# Altium schematic sheet SCHEMATIC.SchDoc(SCHEMATIC)
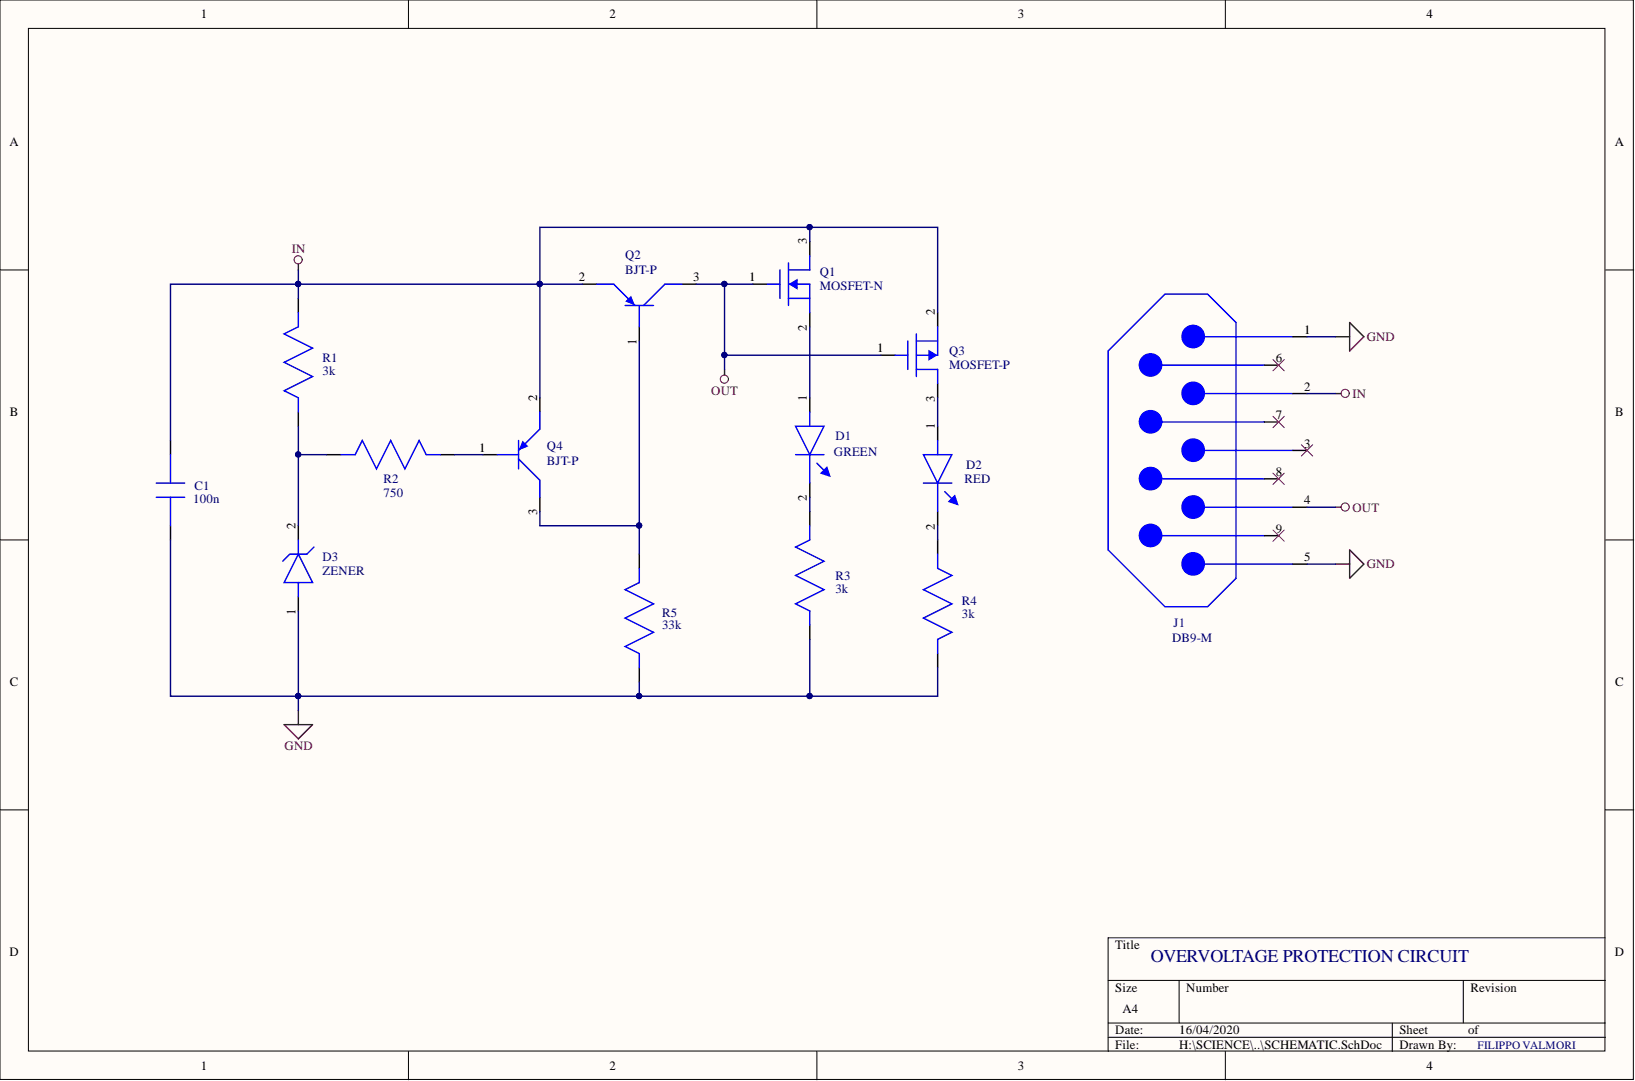
[diagram p0: SCHEMATIC.SchDoc]

## Parts
C1  100n  [CAP-0603]
D1  GREEN  [LED-0603]
D2  RED  [LED-0603]
D3  ZENER  [ZENER-SOD523]
J1  DB9-M  [DB9]
Q1  MOSFET-N  [NMOS-SOT23]
Q2  BJT-P  [BJT-SOT23]
Q3  MOSFET-P  [PMOS-SOT23]
Q4  BJT-P  [BJT-SOT23]
R1  3k  [RES-0805]
R2  750  [RES-0805]
R3  3k  [RES-0805]
R4  3k  [RES-0805]
R5  33k  [RES-0805]

## Nets
GND: C1.2 D3.1 J1.1 J1.5 R3.1 R4.2 R5.1
IN: C1.1 J1.2 Q1.3 Q2.2 Q3.2 Q4.2 R1.2
OUT: J1.4 Q1.1 Q2.3 Q3.1
NetD1_1: D1.1 Q1.2
NetD1_2: D1.2 R3.2
NetD2_1: D2.1 Q3.3
NetD2_2: D2.2 R4.1
NetD3_2: D3.2 R1.1 R2.1
NetQ2_1: Q2.1 Q4.3 R5.2
NetQ4_1: Q4.1 R2.2

Not connected: J1 3 6 7 8 9

## Library data of the parts
C1 = C0603C104M3RACTU: Multilayer Ceramic Capacitors MLCC - SMD/SMT 25volts 0.1uF X7R 20%; Manufacturer: KEMET; Voltage Rating (DC): 25V; Capacitance: 100nF; Width: 0.031inch; Voltage Rating: 25V; Tolerance: 20%; Thickness: 0.034inch; Termination: SMD/SMT; Supplier Part Number 1: 399-1283-6-ND; Supplier 1: Digi-Key; Stock 1: 15620; REACH SVHC: No SVHC; Radiation Hardening: No; Pricing 1: 1=0.1, 10=0.06, 100=0.0267, 500=0.01906, 1000=0.01497 (USD); Packaging: Tape and Reel; Package Quantity: 1; Number of Pins: 2; Mount: Surface Mount,PCB; Min Operating Temperature: -55°C; Max Operating Temperature: 125°C; Manufacturer Part Number: C0603C104M3RACTU; Library Name: LIBRARY_SCHEMATIC.SchLib; Length: 1.6mm; Lead Pitch: 0.7mm; Height: 0.8mm; Dielectric Material: Ceramic; Dielectric: X7R; Depth: 0.8mm; ComponentLink4URL: http://www.alliedelec.com/m/d/9bfe7773041ffe9d406e68bc7b377fdd.pdf?src-supplier=Allied+Electronics; ComponentLink4Description: http://www.alliedelec.com/m/d/9bfe7773041ffe9d406e68bc7b377fdd.pdf?src-supplier=Allied+Electronics; ComponentLink3URL: https://www.verical.com/server-webapp/html/getDatasheet?partID=344365&src-supplier=Verical; ComponentLink3Description: https://www.verical.com/server-webapp/html/getDatasheet?partID=344365&src-supplier=Verical; ComponentLink2URL: http://www.farnell.com/datasheets/2746054.pdf?src-supplier=Newark; ComponentLink2Description: http://www.farnell.com/datasheets/2746054.pdf?src-supplier=Newark; ComponentLink1URL: https://www.verical.com/server-webapp/html/getDatasheet?partID=58462&src-supplier=Verical; ComponentLink1Description: https://www.verical.com/server-webapp/html/getDatasheet?partID=58462&src-supplier=Verical; Component Kind: Standard; Category: Capacitors; Case Code (Metric): 1608; Case Code (Imperial): 0603; Case/Package: 0603
D1 = 598-8070-107F: DIALIGHT - 5988070107F - LED, Green, SMD, 0603, 20 mA, 2 V, 570 nm; Wavelength: 570nm; Viewing Angle: 140°; Test Current: 20mA; Reverse Voltage: 5V; Power Dissipation: 60mW; Number of LEDs: 1; Luminous Intensity: 20mcd; Lens Transparency: Clear; Lens Style: Flat,Rectangular,Diffused,Colorless,Clear; Lens Size: 0.8mm; Lens Color: Colorless,Clear; Forward Voltage: 2V; Dominant Wavelength: 568nm; Width: 0.8mm; Supplier Part Number 1: 350-2035-6-ND; Supplier 1: Digi-Key; Stock 1: 4673; REACH SVHC: No SVHC; Radiation Hardening: No; Pricing 1: 1=0.42, 10=0.3, 100=0.1333, 500=0.09332, 1000=0.07666 (USD); Packaging: Tape and Reel; Package Quantity: 4000; Number of Pins: 2; Number of Elements: 1; Mount: Surface Mount; Min Operating Temperature: -30°C; Max Operating Temperature: 100°C; Manufacturer Part Number: 598-8070-107F; Manufacturer: Dialight; Library Name: LIBRARY_SCHEMATIC.SchLib; Length: 1.6mm; Lead Free: Lead Free; Illumination Color: Green; Height: 0.7mm; Forward Current: 20mA; Depth: 0.8mm; ComponentLink8URL: http://www.dialight.com/Assets/Brochures_And_Catalogs/Indication/SMT_selector_guide.pdf?src-supplier=Future+Electronics; ComponentLink8Description: http://www.dialight.com/Assets/Brochures_And_Catalogs/Indication/SMT_selector_guide.pdf?src-supplier=Future+Electronics; ComponentLink7URL: https://www.verical.com/server-webapp/html/getDatasheet?partID=146438&src-supplier=Verical; ComponentLink7Description: https://www.verical.com/server-webapp/html/getDatasheet?partID=146438&src-supplier=Verical; ComponentLink6URL: http://www.onlinecomponents.com/productFiles/mf-DIA/mdei5980603.pdf?src-supplier=Onlinecomponents.com; ComponentLink6Description: http://www.onlinecomponents.com/productFiles/mf-DIA/mdei5980603.pdf?src-supplier=Onlinecomponents.com; ComponentLink5URL: https://www.alliedelec.com/m/d/745256ca487aea7b70ea31e2d673d5bb.pdf?src-supplier=Allied+Electronics; ComponentLink5Description: https://www.alliedelec.com/m/d/745256ca487aea7b70ea31e2d673d5bb.pdf?src-supplier=Allied+Electronics; ComponentLink4URL: https://media.digikey.com/pdf/Data%20Sheets/Dialight%20PDFs/598_Series_0603_Pkg.pdf?src-supplier=Digi-Key; ComponentLink4Description: https://media.digikey.com/pdf/Data%20Sheets/Dialight%20PDFs/598_Series_0603_Pkg.pdf?src-supplier=Digi-Key; ComponentLink3URL: http://www.farnell.com/datasheets/1720200.pdf?src-supplier=Element14; ComponentLink3Description: http://www.farnell.com/datasheets/1720200.pdf?src-supplier=Element14; ComponentLink2URL: http://uk.rs-online.com/webdocs/0ae2/0900766b80ae2975.pdf?src-supplier=RSComponents; ComponentLink2Description: http://uk.rs-online.com/webdocs/0ae2/0900766b80ae2975.pdf?src-supplier=RSComponents; ComponentLink1URL: http://www.mouser.com/ds/2/109/SMT_selector_guide-267443.pdf?src-supplier=Mouser; ComponentLink1Description: http://www.mouser.com/ds/2/109/SMT_selector_guide-267443.pdf?src-supplier=Mouser; Component Kind: Standard; Color: Green; Category: Diodes; Case Code (Metric): 1608; Case Code (Imperial): 0603; Case/Package: 0603
D2 = 598-8020-107F: DIALIGHT   5988020107F   LED, RED ORANGE, 150MCD, 630NM; Viewing Angle: 140°; Test Current: 20mA; Reverse Voltage: 5V; Power Dissipation: 55mW; Number of LEDs: 1; Lens Transparency: Clear; Lens Style: Flat,Diffused,Colorless,Rectangular,Clear; Lens Size: 0.8mm; Lens Color: Colorless,Clear; Forward Voltage: 2V; Dominant Wavelength: 625nm; Color: Red,Orange; Width: 0.8mm; Wavelength: 630nm; Supplier Part Number 1: 350-2030-6-ND; Supplier 1: Digi-Key; Stock 1: 14692; REACH SVHC: No SVHC; Radiation Hardening: No; Pricing 1: 1=0.47, 10=0.336, 100=0.1495, 500=0.10464, 1000=0.08595 (USD); Packaging: Tape and Reel; Package Quantity: 4000; Number of Pins: 2; Number of Elements: 1; Mount: Surface Mount; Min Operating Temperature: -30°C; Max Operating Temperature: 100°C; Manufacturer Part Number: 598-8020-107F; Manufacturer: Dialight; Luminous Intensity: 150mcd; Library Name: LIBRARY_SCHEMATIC.SchLib; Length: 1.6mm; Lead Free: Lead Free; Illumination Color: Orange; Height: 0.7mm; Forward Current: 20mA; Depth: 0.8mm; ComponentLink6URL: http://www.dialight.com/Assets/Brochures_And_Catalogs/Indication/SMT_selector_guide.pdf?src-supplier=Future+Electronics; ComponentLink6Description: http://www.dialight.com/Assets/Brochures_And_Catalogs/Indication/SMT_selector_guide.pdf?src-supplier=Future+Electronics; ComponentLink5URL: http://www.farnell.com/datasheets/25658.pdf?src-supplier=Element14; ComponentLink5Description: http://www.farnell.com/datasheets/25658.pdf?src-supplier=Element14; ComponentLink4URL: https://www.verical.com/server-webapp/html/getDatasheet?partID=187920&src-supplier=Verical; ComponentLink4Description: https://www.verical.com/server-webapp/html/getDatasheet?partID=187920&src-supplier=Verical; ComponentLink3URL: https://media.digikey.com/pdf/Data%20Sheets/Dialight%20PDFs/598_Series_0603_Pkg.pdf?src-supplier=Digi-Key; ComponentLink3Description: https://media.digikey.com/pdf/Data%20Sheets/Dialight%20PDFs/598_Series_0603_Pkg.pdf?src-supplier=Digi-Key; ComponentLink2URL: http://www.onlinecomponents.com/productFiles/mf-DIA/mdei5980603.pdf?src-supplier=Onlinecomponents.com; ComponentLink2Description: http://www.onlinecomponents.com/productFiles/mf-DIA/mdei5980603.pdf?src-supplier=Onlinecomponents.com; ComponentLink1URL: https://www.alliedelec.com/m/d/67ce6cfd66c5ca65d1535dac34303b05.pdf?src-supplier=Allied+Electronics; ComponentLink1Description: https://www.alliedelec.com/m/d/67ce6cfd66c5ca65d1535dac34303b05.pdf?src-supplier=Allied+Electronics; Component Kind: Standard; Category: Diodes; Case Code (Metric): 1608; Case Code (Imperial): 0603; Case/Package: 0603
D3 = BZT585B10T-7: DIODE ZENER 10V 350MW SOD523; Manufacturer: Diodes; Zener Current: 5mA; Voltage Tolerance: 2%; Peak Reverse Current: 200nA; Impedance: 10R; Forward Voltage: 900mV; ESD Protection: No; Element Configuration: Single; Zener Voltage: 10V; Weight: 3.5E-05oz; Tolerance: 2%; Test Current: 5mA; Supplier Part Number 1: BZT585B10T-7DIDKR-ND; Supplier 1: Digi-Key; Stock 1: 3462; Pricing 1: 1=0.22, 10=0.177, 25=0.148, 100=0.0724, 250=0.07128, 500=0.0604, 1000=0.04197 (USD); Packaging: Tape and Reel; Package Quantity: 3000; Number of Pins: 2; Mount: Surface Mount; Min Operating Temperature: -65°C; Max Reverse Leakage Current: 200nA; Max Power Dissipation: 350mW; Max Operating Temperature: 150°C; Manufacturer Part Number: BZT585B10T-7; Library Name: LIBRARY_SCHEMATIC.SchLib; Contact Plating: Tin,Matte; ComponentLink3URL: https://www.diodes.com/assets/Datasheets/BZT585BxVxT.pdf?src-supplier=Digi-Key; ComponentLink3Description: https://www.diodes.com/assets/Datasheets/BZT585BxVxT.pdf?src-supplier=Digi-Key; ComponentLink2URL: http://diodes.com/datasheets/BZT585BxVxT.pdf?src-supplier=Avnet; ComponentLink2Description: http://diodes.com/datasheets/BZT585BxVxT.pdf?src-supplier=Avnet; ComponentLink1URL: http://www.mouser.com/ds/2/115/BZT585BxVxT-318149.pdf?src-supplier=Mouser; ComponentLink1Description: http://www.mouser.com/ds/2/115/BZT585BxVxT-318149.pdf?src-supplier=Mouser; Component Kind: Standard; Category: Diodes; Case/Package: SOD-523-2
J1 = 09661227801: D-Sub Standard Connectors DSUB SV ML SSDP ANG73-254 09P AU3; Manufacturer: HARTING Technology; Number of Positions: 9; Number of Ports: 1; Current Rating: 7.5A; Connector Type: D-Sub,Plug,Signal; Termination: Solder; Supplier Part Number 1: 1195-4989-ND; Supplier 1: Digi-Key; Stock 1: 102; Pricing 1: 1=4.15, 10=3.984, 25=3.652, 50=3.486, 100=3.32, 250=2.905, 500=2.822, 1000=2.407 (USD); Packaging: Bulk; Package Quantity: 100; Orientation: Right Angle; Number of Terminals: 9; Number of Rows: 2; Mount: Through Hole; Min Operating Temperature: -55°C; Max Operating Temperature: 125°C; Manufacturer Part Number: 09661227801; Library Name: LIBRARY_SCHEMATIC.SchLib; Length: 30.9mm; Housing Material: Polybutylene; Flammability Rating: UL94 V-0; Contact Material: Copper Alloy; ComponentLink1URL: https://b2b.harting.com/files/download/PRD/PDF_TS/0966122X80X_BL01_R29733_100202197DRW002A.PDF?src-supplier=Digi-Key; ComponentLink1Description: https://b2b.harting.com/files/download/PRD/PDF_TS/0966122X80X_BL01_R29733_100202197DRW002A.PDF?src-supplier=Digi-Key; Component Kind: Standard; Category: Connectors
Q1 = 2N7002LT1G: Trans MOSFET N-CH 60V 0.115A 3-Pin SOT-23 T/R; Manufacturer: ON Semiconductor; Turn-On Delay Time: 20ns; Turn-Off Delay Time: 40ns; Threshold Voltage: 2.5V; Rds On Max: 7.5R; Power Dissipation: 300mW; Number of Elements: 1; Max Power Dissipation: 225mW; Input Capacitance: 50pF; Gate to Source Voltage (Vgs): 20V; Element Configuration: Single; Current Rating: 115mA; Width: 1.4mm; Voltage Rating (DC): 60V; Supplier Part Number 1: 2N7002LT1GOSDKR-ND; Supplier 1: Digi-Key; Stock 1: 1300580; REACH SVHC: No SVHC; Radiation Hardening: No; Pricing 1: 1=0.18, 10=0.164, 25=0.1564, 100=0.0688, 250=0.066, 500=0.054, 1000=0.0375 (USD); Packaging: Tape and Reel; Package Quantity: 1; On-State Resistance: 7.5R; Number of Pins: 3; Number of Channels: 1; Nominal Vgs: 2.5V; Min Operating Temperature: -55°C; Max Operating Temperature: 150°C; Manufacturer Part Number: 2N7002LT1G; Library Name: LIBRARY_SCHEMATIC.SchLib; Length: 3.04mm; Lead Free: Lead Free; Height: 1.01mm; Halogen Free: Halogen Free; Drain to Source Voltage (Vdss): 60V; Drain to Source Resistance: 7.5R; Drain to Source Breakdown Voltage: 60V; Continuous Drain Current (ID): 115mA; Contact Plating: Tin,Matte; ComponentLink8URL: http://www.onsemi.com/pub/Collateral/2N7002L-D.PDF?src-supplier=Avnet+Asia; ComponentLink8Description: http://www.onsemi.com/pub/Collateral/2N7002L-D.PDF?src-supplier=Avnet+Asia; ComponentLink7URL: http://www.onsemi.com/pub/Collateral/2N7002L-D.PDF?src-supplier=Avnet+Europe; ComponentLink7Description: http://www.onsemi.com/pub/Collateral/2N7002L-D.PDF?src-supplier=Avnet+Europe; ComponentLink6URL: http://www.onsemi.com/pub/Collateral/2N7002L-D.PDF?src-supplier=Avnet; ComponentLink6Description: http://www.onsemi.com/pub/Collateral/2N7002L-D.PDF?src-supplier=Avnet; ComponentLink5URL: http://www.onsemi.com/pub_link/Collateral/2N7002L-D.PDF?src-supplier=Future+Electronics; ComponentLink5Description: http://www.onsemi.com/pub_link/Collateral/2N7002L-D.PDF?src-supplier=Future+Electronics; ComponentLink4URL: http://www.farnell.com/datasheets/2354034.pdf?src-supplier=Element14; ComponentLink4Description: http://www.farnell.com/datasheets/2354034.pdf?src-supplier=Element14; ComponentLink3URL: http://www.farnell.com/datasheets/1747988.pdf?src-supplier=Newark; ComponentLink3Description: http://www.farnell.com/datasheets/1747988.pdf?src-supplier=Newark; ComponentLink2URL: http://www.farnell.com/datasheets/1747988.pdf?src-supplier=Element14; ComponentLink2Description: http://www.farnell.com/datasheets/1747988.pdf?src-supplier=Element14; ComponentLink1URL: http://www.onsemi.com/pub/Collateral/2N7002L-D.PDF?src-supplier=Digi-Key; ComponentLink1Description: http://www.onsemi.com/pub/Collateral/2N7002L-D.PDF?src-supplier=Digi-Key; Component Kind: Standard; Category: Transistors; Case/Package: SOT-23-3
Q2 Q4 = MMBT2907ALT1G: MMBT2907AL Series 60 V 600 mA SMT PNP General Purpose Transistor - SOT-23; Manufacturer: ON Semiconductor; Voltage Rating (DC): -60V; Transition Frequency: 200MHz; Power Dissipation: 300mW; Number of Elements: 1; Max Collector Current: 600mA; Max Breakdown Voltage: 60V; hFE Min: 75; Emitter Base Voltage (VEBO): 5V; Element Configuration: Single; Current Rating: -600mA; Collector Emitter Saturation Voltage: -1.6V; Width: 1.3mm; Type: General Purpose; Supplier Part Number 1: MMBT2907ALT1GOSCT-ND; Supplier 1: Digi-Key; Stock 1: 211221; REACH SVHC: No SVHC; Radiation Hardening: No; Pricing 1: 1=0.15, 10=0.134, 25=0.1276, 100=0.0561, 250=0.05384, 500=0.04406, 1000=0.0306 (USD); Polarity: PNP; Packaging: Tape and Reel; Package Quantity: 3000; Number of Pins: 3; Min Operating Temperature: -55°C; Max Power Dissipation: 300mW; Max Operating Temperature: 150°C; Max Frequency: 200MHz; Manufacturer Part Number: MMBT2907ALT1G; Library Name: LIBRARY_SCHEMATIC.SchLib; Length: 2.9mm; Lead Free: Lead Free; Height: 0.94mm; Gain Bandwidth Product: 200MHz; Frequency: 200MHz; Contact Plating: Tin,Matte; ComponentLink9URL: https://www.verical.com/server-webapp/html/getDatasheet?partID=44366&src-supplier=Verical; ComponentLink9Description: https://www.verical.com/server-webapp/html/getDatasheet?partID=44366&src-supplier=Verical; ComponentLink8URL: http://www.farnell.com/datasheets/2028683.pdf?src-supplier=Element14; ComponentLink8Description: http://www.farnell.com/datasheets/2028683.pdf?src-supplier=Element14; ComponentLink7URL: http://www.farnell.com/datasheets/1744610.pdf?src-supplier=Element14; ComponentLink7Description: http://www.farnell.com/datasheets/1744610.pdf?src-supplier=Element14; ComponentLink6URL: http://www.onsemi.com/pub/Collateral/MMBT2907ALT1-D.PDF?src-supplier=Avnet; ComponentLink6Description: http://www.onsemi.com/pub/Collateral/MMBT2907ALT1-D.PDF?src-supplier=Avnet; ComponentLink5URL: http://www.onsemi.com/pub/Collateral/MMBT2907ALT1-D.PDF?src-supplier=Future+Electronics; ComponentLink5Description: http://www.onsemi.com/pub/Collateral/MMBT2907ALT1-D.PDF?src-supplier=Future+Electronics; ComponentLink4URL: http://www.onsemi.com/pub/Collateral/MMBT2907ALT1-D.PDF?src-supplier=Element14; ComponentLink4Description: http://www.onsemi.com/pub/Collateral/MMBT2907ALT1-D.PDF?src-supplier=Element14; ComponentLink3URL: http://www.farnell.com/datasheets/2028683.pdf?src-supplier=Farnell; ComponentLink3Description: http://www.farnell.com/datasheets/2028683.pdf?src-supplier=Farnell; ComponentLink2URL: https://www.alliedelec.com/m/d/f1da87220e07dfe91b55a9a93f81e6fa.pdf?src-supplier=Allied+Electronics; ComponentLink2Description: https://www.alliedelec.com/m/d/f1da87220e07dfe91b55a9a93f81e6fa.pdf?src-supplier=Allied+Electronics; ComponentLink1URL: http://www.onsemi.com/pub/Collateral/MMBT2907ALT1-D.PDF?src-supplier=Digi-Key; ComponentLink1Description: http://www.onsemi.com/pub/Collateral/MMBT2907ALT1-D.PDF?src-supplier=Digi-Key; Component Kind: Standard; Collector Emitter Voltage (VCEO): 60V; Collector Emitter Breakdown Voltage: -60V; Collector Base Voltage (VCBO): 60V; Category: Transistors; Case/Package: SOT-23-3
Q3 = TP0610K-T1-E3: MOSFET P-CH 60V 185MA SOT23-3; Manufacturer: Vishay; Turn-On Delay Time: 20ns; Threshold Voltage: -3V; Rds On Max: 6R; Power Dissipation: 350mW; On-State Resistance: 10R; Number of Elements: 1; Input Capacitance: 23pF; Gate to Source Voltage (Vgs): 20V; Element Configuration: Single; Drain to Source Breakdown Voltage: -60V; Width: 1.4mm; Weight: 0.050717oz; Supplier Part Number 1: TP0610K-T1-E3DKR-ND; Supplier 1: Digi-Key; Stock 1: 130184; REACH SVHC: Unknown; Radiation Hardening: No; Pricing 1: 1=0.39, 10=0.313, 25=0.2868, 100=0.1801, 250=0.17808, 500=0.16676, 1000=0.11339 (USD); Packaging: Tape and Reel; Package Quantity: 1; Number of Pins: 3; Number of Channels: 1; Nominal Vgs: -3V; Mount: Surface Mount; Min Operating Temperature: -55°C; Max Power Dissipation: 350mW; Max Operating Temperature: 150°C; Manufacturer Part Number: TP0610K-T1-E3; Library Name: LIBRARY_SCHEMATIC.SchLib; Length: 3.04mm; Lead Free: Lead Free; Height: 1.02mm; Drain to Source Voltage (Vdss): 60V; Drain to Source Resistance: 10R; Continuous Drain Current (ID): 185mA; ComponentLink10URL: http://datasheet.iiic.cc/vishay_[siliconix]/TP0610K-T1-E3.pdf?src-supplier=IIIC; ComponentLink10Description: http://datasheet.iiic.cc/vishay_[siliconix]/TP0610K-T1-E3.pdf?src-supplier=IIIC; ComponentLink9URL: http://www.vishay.com/docs/71411/tp0610k.pdf?src-supplier=Digi-Key; ComponentLink9Description: http://www.vishay.com/docs/71411/tp0610k.pdf?src-supplier=Digi-Key; ComponentLink8URL: http://www.farnell.com/datasheets/2049195.pdf?src-supplier=Element14; ComponentLink8Description: http://www.farnell.com/datasheets/2049195.pdf?src-supplier=Element14; ComponentLink7URL: http://www.vishay.com/docs/71411/tp0610k.pdf?src-supplier=Avnet; ComponentLink7Description: http://www.vishay.com/docs/71411/tp0610k.pdf?src-supplier=Avnet; ComponentLink6URL: http://www.farnell.com/datasheets/1747937.pdf?src-supplier=Element14; ComponentLink6Description: http://www.farnell.com/datasheets/1747937.pdf?src-supplier=Element14; ComponentLink5URL: http://www.farnell.com/datasheets/1747937.pdf?src-supplier=Farnell; ComponentLink5Description: http://www.farnell.com/datasheets/1747937.pdf?src-supplier=Farnell; ComponentLink4URL: http://www.vishay.com/docs/71411/tp0610k.pdf?src-supplier=Avnet+Europe; ComponentLink4Description: http://www.vishay.com/docs/71411/tp0610k.pdf?src-supplier=Avnet+Europe; ComponentLink3URL: https://www.alliedelec.com/m/d/8f71ef69d286bdc1948cb77e0f9cb5b1.pdf?src-supplier=Allied+Electronics; ComponentLink3Description: https://www.alliedelec.com/m/d/8f71ef69d286bdc1948cb77e0f9cb5b1.pdf?src-supplier=Allied+Electronics; ComponentLink2URL: http://www.farnell.com/datasheets/2049195.pdf?src-supplier=Newark; ComponentLink2Description: http://www.farnell.com/datasheets/2049195.pdf?src-supplier=Newark; ComponentLink1URL: https://www.verical.com/server-webapp/html/getDatasheet?partID=110418&src-supplier=Verical; ComponentLink1Description: https://www.verical.com/server-webapp/html/getDatasheet?partID=110418&src-supplier=Verical; Component Kind: Standard; Category: Transistors; Case/Package: TO-236
R1 R3 R4 = RNCP0805FTD3K01: RES SMD 3.01K OHM 1% 1/4W 0805; Manufacturer: Stackpole Electronics; Temperature Coefficient: 100ppm/°C; Resistance: 3.01kR; Max Power Dissipation: 250mW; Width: 0.049inch; Tolerance: 1%; Supplier Part Number 1: RNCP0805FTD3K01DKR-ND; Supplier 1: Digi-Key; Stock 1: 3825; Radiation Hardening: No; Pricing 1: 1=0.1, 10=0.069, 100=0.0264, 1000=0.01162, 2500=0.01003 (USD); Packaging: Tape and Reel; Number of Terminations: 2; Mount: Surface Mount; Min Operating Temperature: -55°C; Max Operating Temperature: 155°C; Manufacturer Part Number: RNCP0805FTD3K01; Library Name: LIBRARY_SCHEMATIC.SchLib; Length: 0.079inch; Height: 0.024inch; Features: Moisture Resistant,Anti-Sulfur; Contact Plating: Tin,Matte; Composition: Thin Film; ComponentLink3URL: https://www.seielect.com/Catalog/SEI-rncp.pdf?src-supplier=Avnet; ComponentLink3Description: https://www.seielect.com/Catalog/SEI-rncp.pdf?src-supplier=Avnet; ComponentLink2URL: https://www.seielect.com/catalog/SEI-Packaging.pdf?src-supplier=Digi-Key; ComponentLink2Description: https://www.seielect.com/catalog/SEI-Packaging.pdf?src-supplier=Digi-Key; ComponentLink1URL: http://www.seielect.com/Catalog/SEI-rncp.pdf?src-supplier=Digi-Key; ComponentLink1Description: http://www.seielect.com/Catalog/SEI-rncp.pdf?src-supplier=Digi-Key; Component Kind: Standard; Category: Resistors; Case Code (Metric): 2012; Case Code (Imperial): 0805; Case/Package: 0805
R2 = RNCP0805FTD750R: RES SMD 750 OHM 1% 1/4W 0805; Manufacturer: Stackpole Electronics; Temperature Coefficient: 100ppm/°C; Resistance: 750R; Max Power Dissipation: 250mW; Width: 0.049inch; Tolerance: 1%; Supplier Part Number 1: RNCP0805FTD750RDKR-ND; Supplier 1: Digi-Key; Stock 1: 16430; Radiation Hardening: No; Pricing 1: 1=0.1, 10=0.072, 100=0.0277, 1000=0.0122, 2500=0.01053 (USD); Packaging: Tape and Reel; Number of Terminations: 2; Mount: Surface Mount; Min Operating Temperature: -55°C; Max Operating Temperature: 155°C; Manufacturer Part Number: RNCP0805FTD750R; Library Name: LIBRARY_SCHEMATIC.SchLib; Length: 0.079inch; Lead Free: Lead Free; Height: 0.024inch; Features: Moisture Resistant,Anti-Sulfur; Contact Plating: Tin,Matte; Composition: Thin Film; ComponentLink3URL: https://www.seielect.com/catalog/SEI-Packaging.pdf?src-supplier=Digi-Key; ComponentLink3Description: https://www.seielect.com/catalog/SEI-Packaging.pdf?src-supplier=Digi-Key; ComponentLink2URL: https://www.seielect.com/Catalog/SEI-rncp.pdf?src-supplier=Avnet; ComponentLink2Description: https://www.seielect.com/Catalog/SEI-rncp.pdf?src-supplier=Avnet; ComponentLink1URL: http://www.seielect.com/Catalog/SEI-rncp.pdf?src-supplier=Digi-Key; ComponentLink1Description: http://www.seielect.com/Catalog/SEI-rncp.pdf?src-supplier=Digi-Key; Component Kind: Standard; Category: Resistors; Case Code (Metric): 2012; Case Code (Imperial): 0805; Case/Package: 0805
R5 = RNCP0805FTD33K2: RES SMD 33.2K OHM 1% 1/4W 0805; Manufacturer: Stackpole Electronics; Temperature Coefficient: 100ppm/°C; Resistance: 33.2kR; Max Power Dissipation: 250mW; Width: 0.049inch; Tolerance: 1%; Supplier Part Number 1: RNCP0805FTD33K2DKR-ND; Supplier 1: Digi-Key; Stock 1: 3563; Pricing 1: 1=0.1, 10=0.069, 100=0.0264, 1000=0.01162, 2500=0.01003 (USD); Packaging: Tape and Reel; Number of Terminations: 2; Mount: Surface Mount; Min Operating Temperature: -55°C; Max Operating Temperature: 155°C; Manufacturer Part Number: RNCP0805FTD33K2; Library Name: LIBRARY_SCHEMATIC.SchLib; Length: 0.079inch; Height: 0.024inch; Features: Moisture Resistant,Anti-Sulfur; Composition: Thin Film; ComponentLink2URL: https://www.seielect.com/catalog/SEI-Packaging.pdf?src-supplier=Digi-Key; ComponentLink2Description: https://www.seielect.com/catalog/SEI-Packaging.pdf?src-supplier=Digi-Key; ComponentLink1URL: http://www.seielect.com/Catalog/SEI-rncp.pdf?src-supplier=Digi-Key; ComponentLink1Description: http://www.seielect.com/Catalog/SEI-rncp.pdf?src-supplier=Digi-Key; Component Kind: Standard; Category: Resistors; Case Code (Metric): 2012; Case Code (Imperial): 0805; Case/Package: 0805
